# Altium schematic sheet SCH2.SchDoc
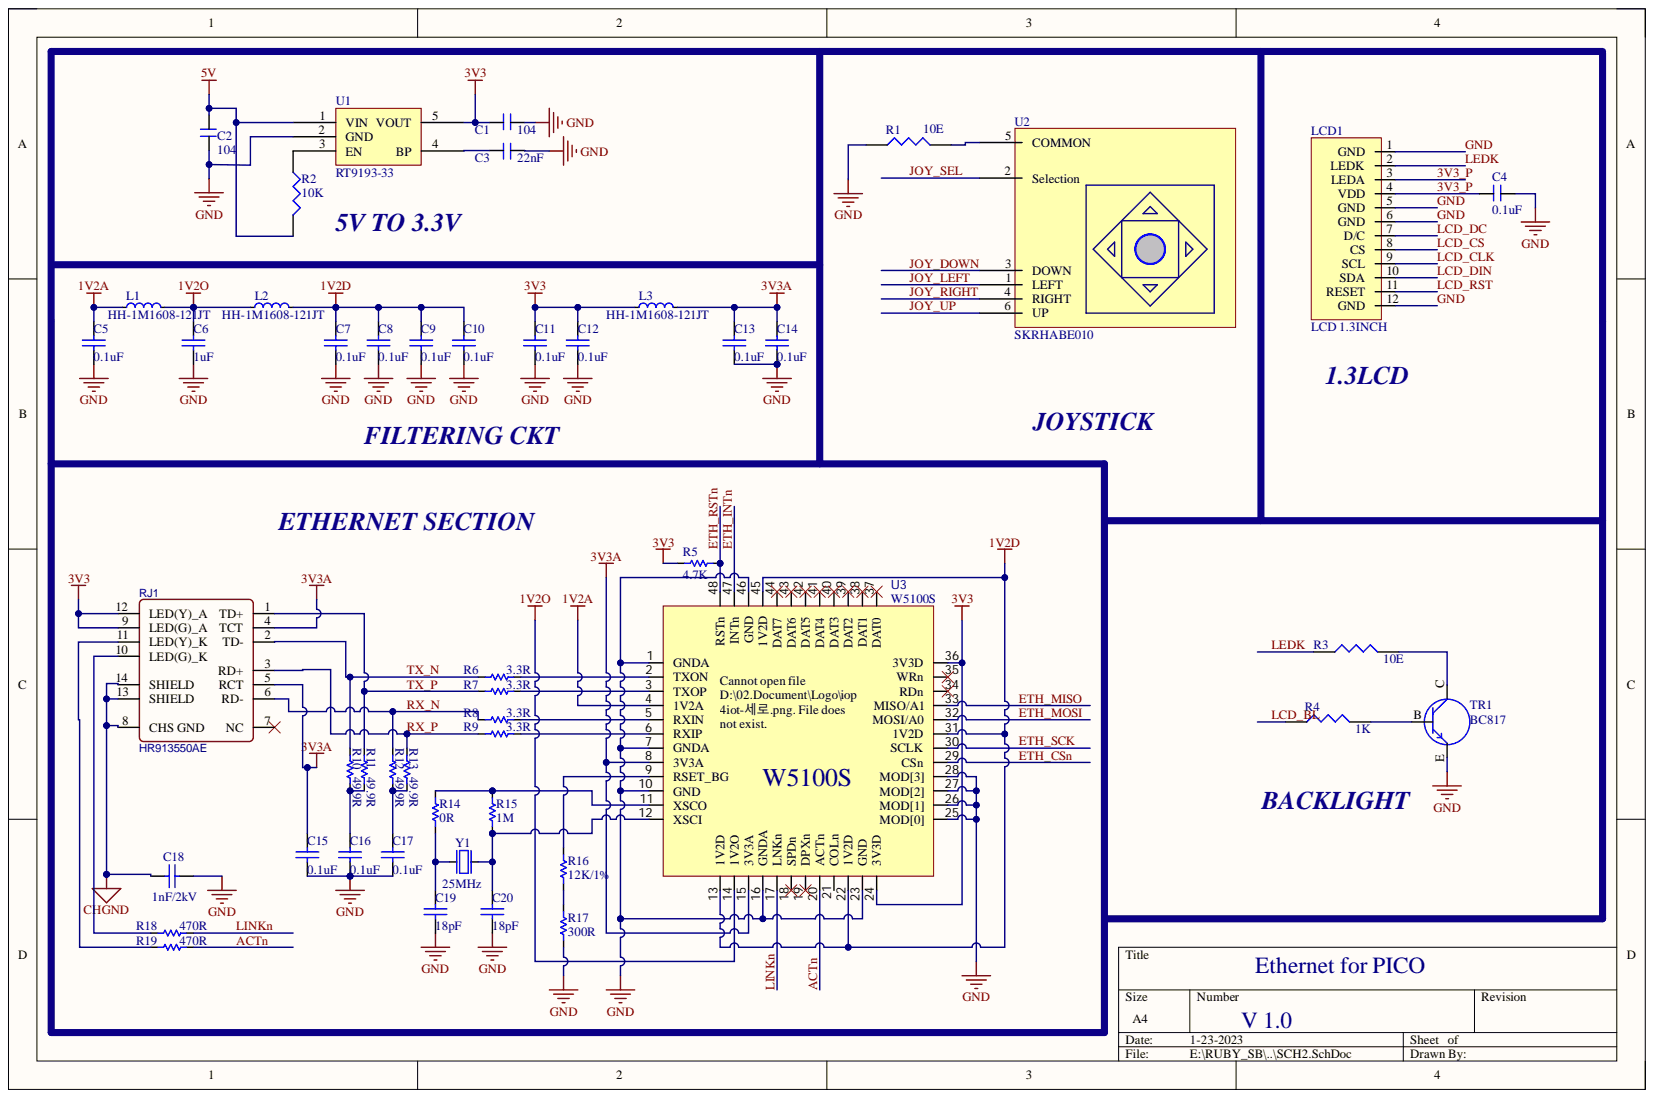
[diagram p0: SCH2.SchDoc]

## Parts
C1  104  [R_0603]
C2  104  [C_0603]
C3  22nF  [C_0603]
C4  0.1uF  [C_0603]
C5  0.1uF  [C_0603]
C6  1uF  [C_0603]
C7  0.1uF  [C_0603]
C8  0.1uF  [R_0603]
C9  0.1uF  [C_0603]
C10  0.1uF  [C_0603]
C11  0.1uF  [C_0603]
C12  0.1uF  [C_0603]
C13  0.1uF  [C_0603]
C14  0.1uF  [C_0603]
C15  0.1uF  [C_0603]
C16  0.1uF  [C_0603]
C17  0.1uF  [C_0603]
C18  1nF/2kV  [C_1808]
C19  18pF  [C_0603]
C20  18pF  [C_0603]
L1  HH-1M1608-121JT  [R_0603]
L2  HH-1M1608-121JT  [R_0603]
L3  HH-1M1608-121JT  [R_0603]
LCD1  LCD 1.3INCH  [1.3inch LCD]
R1  10E  [R_0603]
R2  10K  [R_0603]
R3  10E  [R_0603]
R4  1K  [R_0603]
R5  4.7K  [R_0603]
R6  3.3R  [R_0603]
R7  3.3R  [R_0603]
R8  3.3R  [R_0603]
R9  3.3R  [R_0603]
R10  49.9R  [R_0603]
R11  49.9R  [R_0603]
R12  49.9R  [R_0603]
R13  49.9R  [R_0603]
R14  0R  [R_0603]
R15  1M  [R_0603]
R16  12K/1%  [R_0603]
R17  300R  [R_0603]
R18  470R  [R_0603]
R19  470R  [R_0603]
RJ1  HR913550AE  [RJ45]
TR1  BC817  [SOT23]
U1  RT9193-33  [SOT23-5]
U2  SKRHABE010  [JOY_STICK]
U3  W5100S  [W5100S]
Y1  25MHz  [crystal]

## Nets
1V2A: C5.2 L1.1 U3.4(1V2A)
1V2D: C7.2 C8.2 C9.2 C10.2 L2.2 U3.13(1V2D) U3.22(1V2D) U3.31(1V2D) U3.45(1V2D)
1V2O: C6.2 L1.2 L2.1 U3.14(1V2O)
3V3: C1.2 C11.2 C12.2 L3.1 R5.1 RJ1.9(LED(G)_A) RJ1.12(LED(Y)_A) U1.5(VOUT) U3.24(3V3D) U3.36(3V3D)
3V3A: C13.2 C14.2 C15.2 L3.2 RJ1.4(TCT) RJ1.5(RCT) U3.8(3V3A) U3.15(3V3A)
5V: C2.1 R2.2 U1.1(VIN)
CHGND: C18.2 RJ1.8(CHS_GND) RJ1.13(SHIELD) RJ1.14(SHIELD)
3V3_P: C4.2 LCD1.3(LEDA) LCD1.4(VDD)
ACTn: R19.2 U3.20(ACTn)
ETH_CSn: U3.29(CSn)
ETH_INTn: U3.47(INTn)
ETH_MISO: U3.33(MISO/A1)
ETH_MOSI: U3.32(MOSI/A0)
ETH_RSTn: R5.2 U3.48(RSTn)
ETH_SCK: U3.30(SCLK)
GND: C1.1 C2.2 C3.2 C4.1 C5.1 C6.1 C7.1 C8.1 C9.1 C10.1 C11.1 C12.1 C13.1 C14.1 C15.1 C16.1 C17.1 C18.1 C19.1 C20.1 LCD1.1(GND) LCD1.5(GND) LCD1.6(GND) LCD1.12(GND) R1.1 R17.2 TR1.E U1.2(GND) U3.1(GNDA) U3.7(GNDA) U3.10(GND) U3.16(GNDA) U3.23(GND) U3.25(MOD[0]) U3.26(MOD[1]) U3.27(MOD[2]) U3.28(MOD[3]) U3.46(GND) Y1.2 Y1.4
JOY_DOWN: U2.3(DOWN)
JOY_LEFT: U2.1(LEFT)
JOY_RIGHT: U2.4(RIGHT)
JOY_SEL: U2.2(Selection)
JOY_UP: U2.6(UP)
LCD_BL: R4.1
LCD_CLK: LCD1.9(SCL)
LCD_CS: LCD1.8(CS)
LCD_DC: LCD1.7(D/C)
LCD_DIN: LCD1.10(SDA)
LCD_RST: LCD1.11(RESET)
LEDK: LCD1.2(LEDK) R3.1
LINKn: R18.2 U3.17(LNKn)
RX_N: R8.1 R12.2 RJ1.6(RD-)
RX_P: R9.1 R13.2 RJ1.3(RD+)
TX_N: R6.1 R10.2 RJ1.2(TD-)
TX_P: R7.1 R11.2 RJ1.1(TD+)
NetC3_1: C3.1 U1.4(BP)
NetC16_2: C16.2 R10.1 R11.1
NetC17_2: C17.2 R12.1 R13.1
NetC19_2: C19.2 R14.1 Y1.1
NetC20_2: C20.2 R15.1 U3.12(XSCI) Y1.3
NetR1_2: R1.2 U2.5(COMMON)
NetR2_1: R2.1 U1.3(EN)
NetR3_2: R3.2 TR1.C
NetR4_2: R4.2 TR1.B
NetR6_2: R6.2 U3.2(TXON)
NetR7_2: R7.2 U3.3(TXOP)
NetR8_2: R8.2 U3.5(RXIN)
NetR9_2: R9.2 U3.6(RXIP)
NetR14_2: R14.2 R15.2 U3.11(XSCO)
NetR16_1: R16.1 U3.9(RSET_BG)
NetR16_2: R16.2 R17.1
NetR18_1: R18.1 RJ1.10(LED(G)_K)
NetR19_1: R19.1 RJ1.11(LED(Y)_K)

Not connected: RJ1 7(NC); U3 18(SPDn) 19(DPXn) 21(COLn) 34(RDn) 35(WRn) 37(DAT0) 38(DAT1) 39(DAT2) 40(DAT3) 41(DAT4) 42(DAT5) 43(DAT6) 44(DAT7)

## Library data of the parts
C1-C4 = CAP_NONPOLAR: Component Kind: Standard; Library Name: dxp_pasl_lib.IntLib
C5-C17 C19 C20 = CAP-0402: Ceramic CAP 0402(1005); Component Kind: Standard; Library Name: Scott_lib.IntLib
C18 = CAP-1808: Ceramic CAP 1808(4520); Component Kind: Standard; Library Name: Scott_lib.IntLib
L1-L3 = IND-0603: Inductor 0603(1608); Component Kind: Standard; Library Name: Scott_lib.IntLib
LCD1 = LCD 1.3INCH: 1.3inch LCD, 240RGDX240 DOT MATIRX TFT LCD MODULE; Component Kind: Standard; Library Name: dxp_pasl_lib.IntLib
R1-R4 = RES: Component Kind: Standard; Library Name: dxp_pasl_lib.IntLib
R5-R19 = RES-0402: Component Kind: Standard; Description: RES-0402; Library Name: Scott_lib.IntLib
RJ1 = RJ45: BOM_Manufacturer: Zhongshan HanRun Elec; Contributor: LCSC; BOM_JLCPCB Part Class: Extended Part; BOM_Manufacturer Part: HR913550AE; BOM_Supplier: LCSC; BOM_Supplier Part: C192706; Component Kind: Standard; spicePre: R; spiceSymbolName: HR913550AE; Library Name: MIX.SchLib
TR1 = TRANSISTER_NPN: Component Kind: Standard; Library Name: dxp_pasl_lib.IntLib
U2 = SKRHABE010: SMD 5-way Tactile Switches RoHS #C139794; Component Kind: Standard; Library Name: dxp_pasl_lib.IntLib
U3 = W5100S: WIZnet Hardwired TCP/IP Chip; Component Kind: Standard; Library Name: Scott_lib.IntLib
Y1 = OSC-82J0626-25MHz: X-tal 25MHz,3.2x2.5 SMD, CL=18pF; Component Kind: Standard; Library Name: Scott_lib.IntLib
U1 = RT9193-33: Component Kind: Standard; Library Name: dxp_pasl_lib.IntLib
